# Altium schematic sheet SH2_BI-Gr_Potentiostat_USB_PwrIN_R0.05.00.SchDoc(SH2_BI-Gr_Potentiostat_USB_PwrIN_R0.05.00)
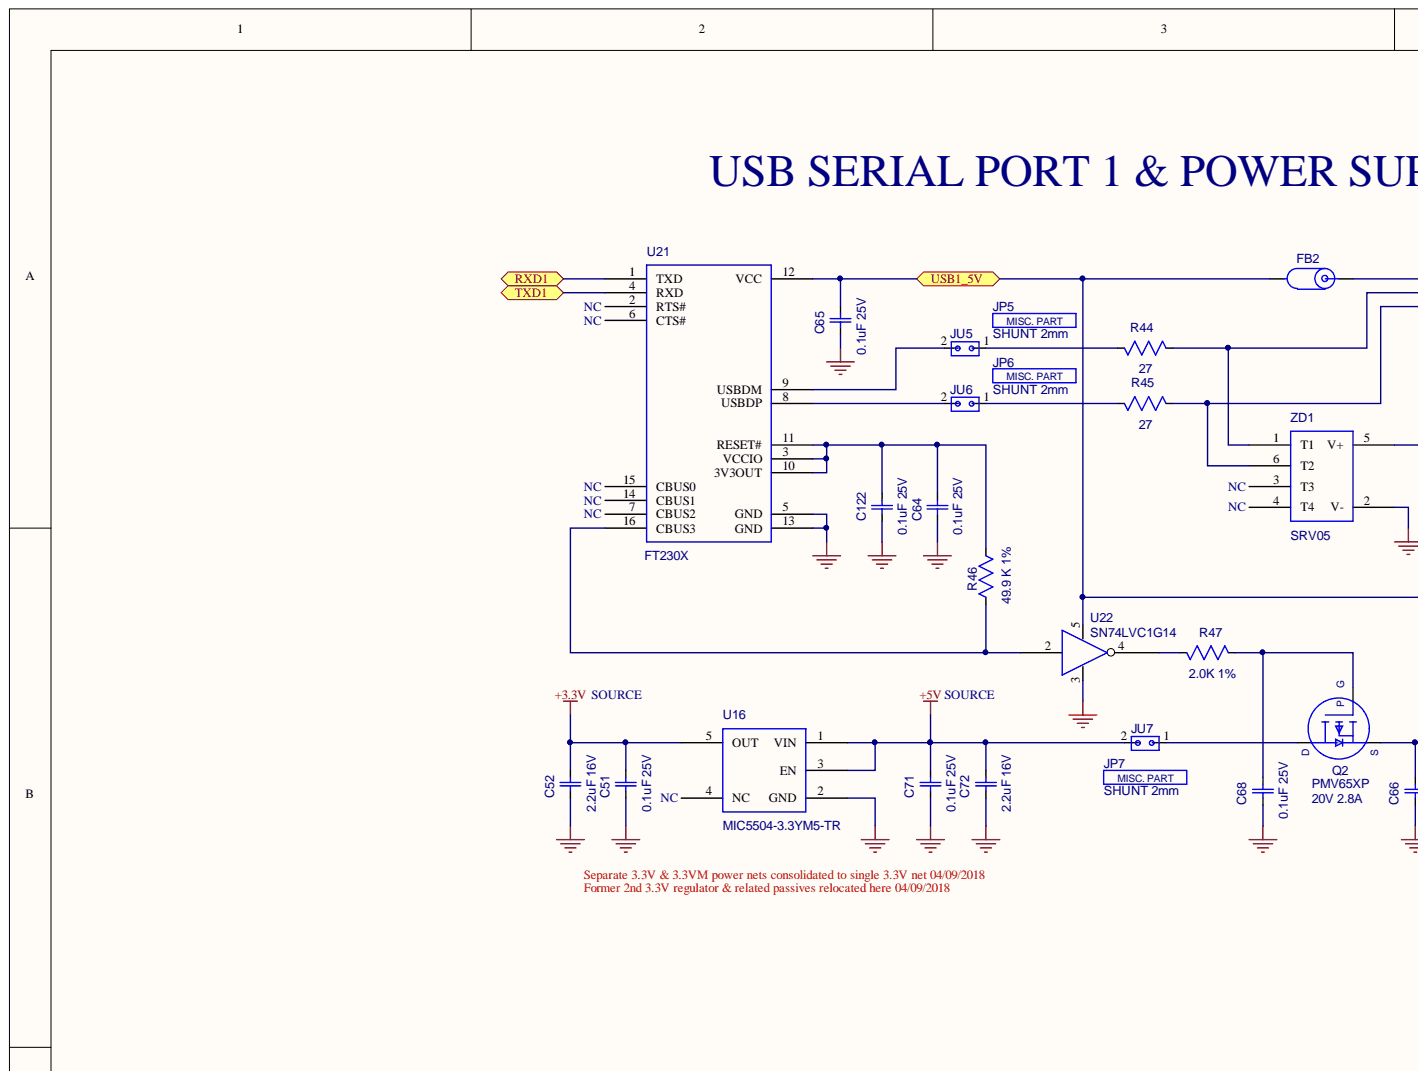
[diagram p0: SH2_BI-Gr_Potentiostat_USB_PwrIN_R0.05.00.SchDoc, top left]
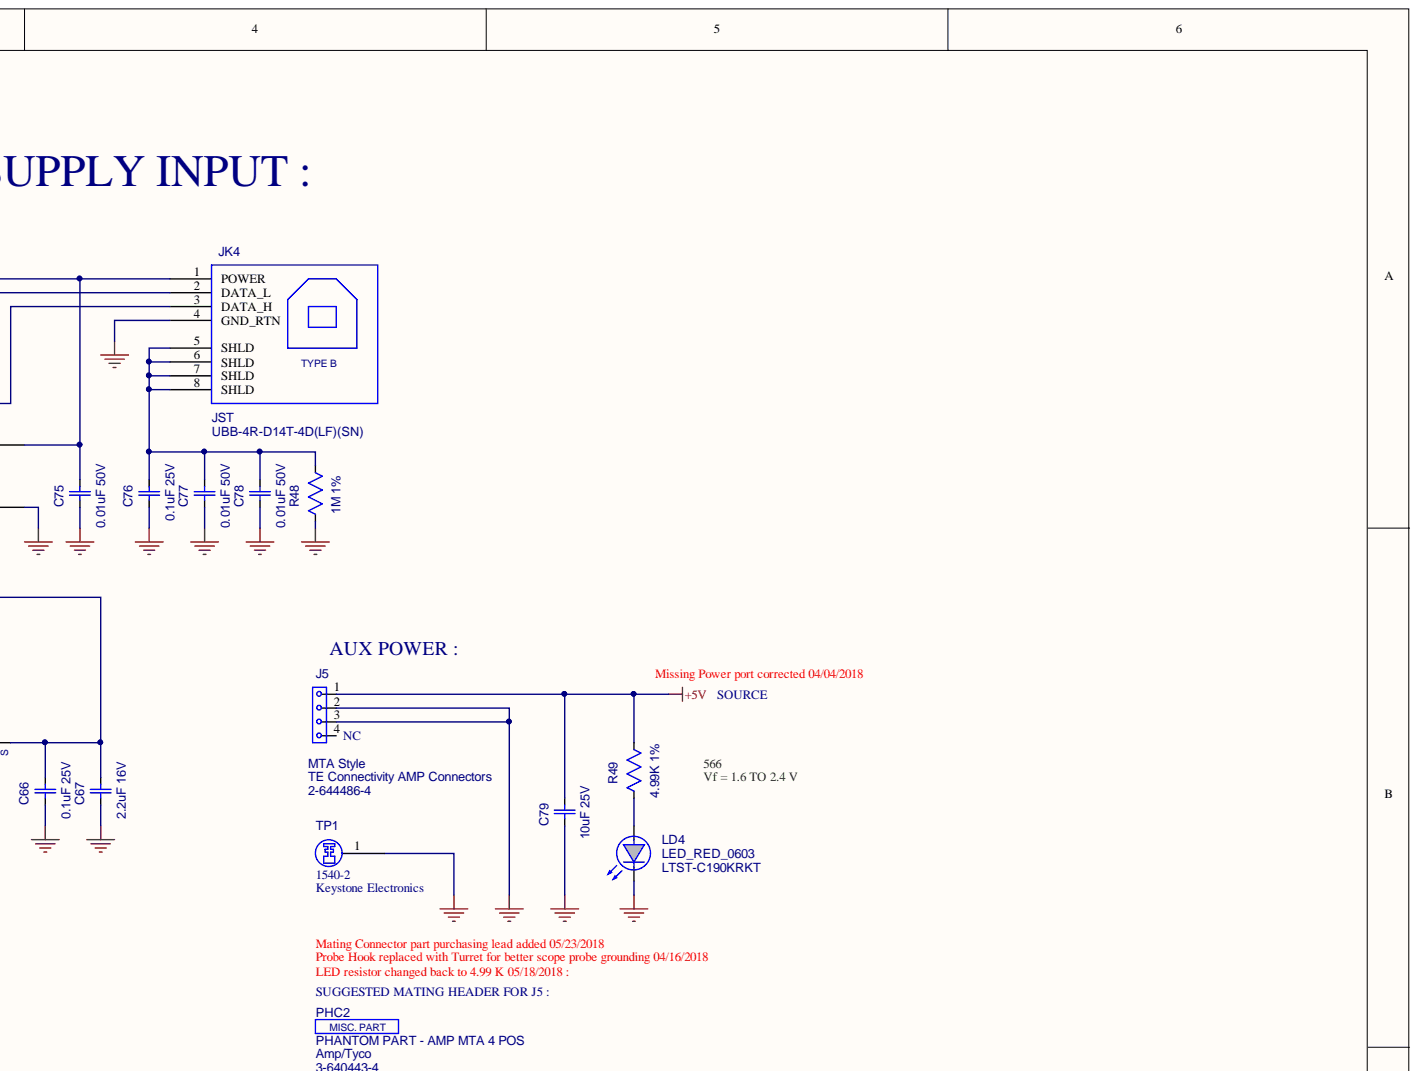
[diagram p1: SH2_BI-Gr_Potentiostat_USB_PwrIN_R0.05.00.SchDoc, top right]
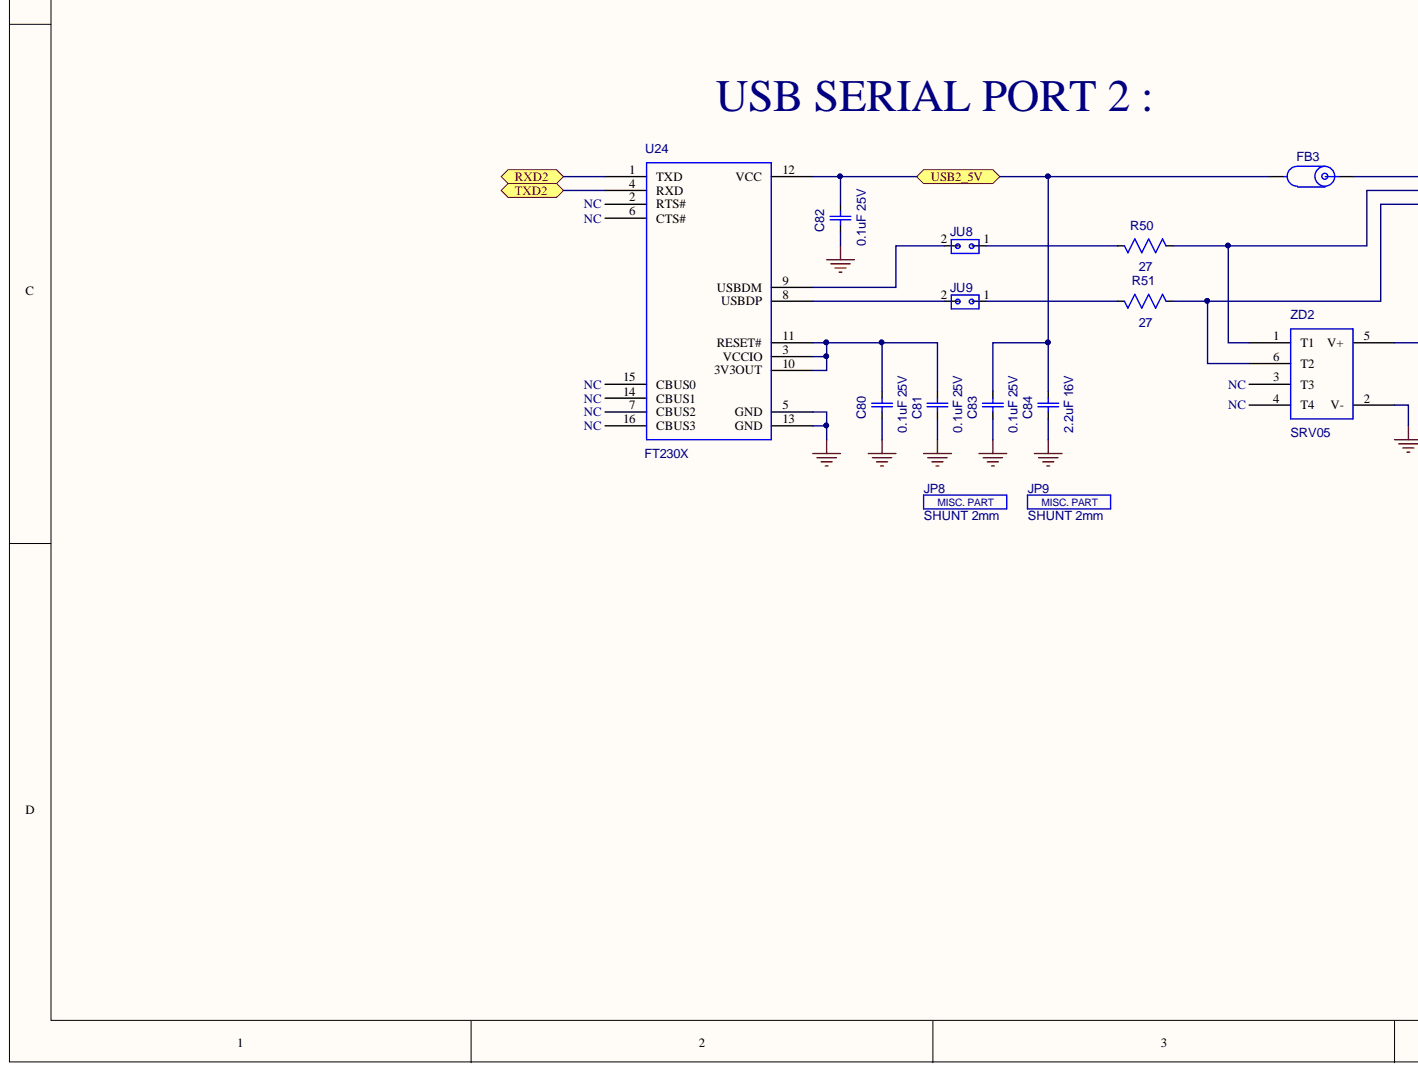
[diagram p2: SH2_BI-Gr_Potentiostat_USB_PwrIN_R0.05.00.SchDoc, bottom left]
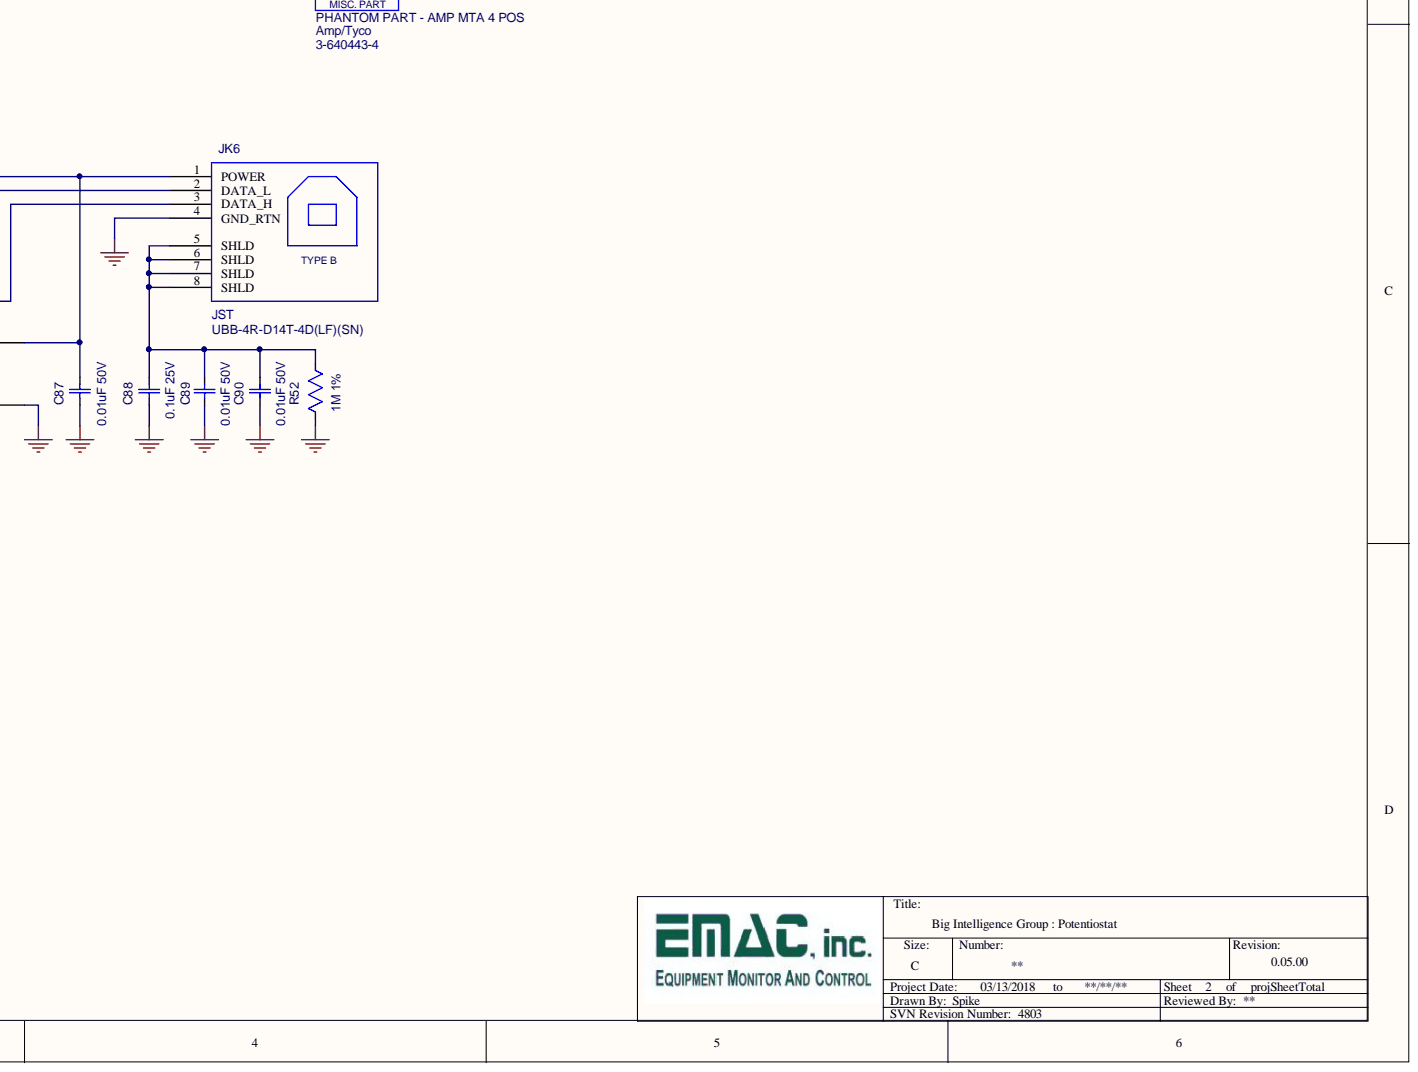
[diagram p3: SH2_BI-Gr_Potentiostat_USB_PwrIN_R0.05.00.SchDoc, bottom right]

## Parts
C51  0.1uF 25V  Notes: 25V  [CC0603_IPC_L]
C52  2.2uF 16V  value 2.2uF  Notes: 16V  [CC0603_IPC_L]
C64  0.1uF 25V  Notes: 25V  [CC0603_IPC_L]
C65  0.1uF 25V  Notes: 25V  [CC0603_IPC_L]
C66  0.1uF 25V  Notes: 25V  [CC0603_IPC_L]
C67  2.2uF 16V  value 2.2uF  Notes: 16V  [CC0603_IPC_L]
C68  0.1uF 25V  Notes: 25V  [CC0603_IPC_L]
C71  0.1uF 25V  Notes: 25V  [CC0603_IPC_L]
C72  2.2uF 16V  value 2.2uF  Notes: 16V  [CC0603_IPC_L]
C75  0.01uF 50V  value 0.01uF  Notes: 50V  [CC0603_IPC_L]
C76  0.1uF 25V  Notes: 25V  [CC0603_IPC_L]
C77  0.01uF 50V  value 0.01uF  Notes: 50V  [CC0603_IPC_L]
C78  0.01uF 50V  value 0.01uF  Notes: 50V  [CC0603_IPC_L]
C79  10uF 25V  value 10uF  Notes: 25V  [CC0805_IPC_L]
C80  0.1uF 25V  Notes: 25V  [CC0603_IPC_L]
C81  0.1uF 25V  Notes: 25V  [CC0603_IPC_L]
C82  0.1uF 25V  Notes: 25V  [CC0603_IPC_L]
C83  0.1uF 25V  Notes: 25V  [CC0603_IPC_L]
C84  2.2uF 16V  value 2.2uF  Notes: 16V  [CC0603_IPC_L]
C87  0.01uF 50V  value 0.01uF  Notes: 50V  [CC0603_IPC_L]
C88  0.1uF 25V  Notes: 25V  [CC0603_IPC_L]
C89  0.01uF 50V  value 0.01uF  Notes: 50V  [CC0603_IPC_L]
C90  0.01uF 50V  value 0.01uF  Notes: 50V  [CC0603_IPC_L]
C122  0.1uF 25V  Notes: 25V  [CC0603_IPC_L]
FB2  RFchoke 4.0A  [LC0603_IPC_L]  (value not drawn)
FB3  RFchoke 4.0A  [LC0603_IPC_L]  (value not drawn)
J5  2-644486-4  Notes: MTA Style  [CONN_VEHDR_AMP644486-4_PAT]
JK4  Manufacturer PN: UBB-4R-D14T-4D(LF)(SN)  Manufacturer: JST  [CONN_USB_HE_TYPEB_JST_PAT1]
JK6  Manufacturer PN: UBB-4R-D14T-4D(LF)(SN)  Manufacturer: JST  [CONN_USB_HE_TYPEB_JST_PAT1]
JP5  SHUNT 2mm  [SHUNT_2MM_PAT]
JP6  SHUNT 2mm  [SHUNT_2MM_PAT]
JP7  SHUNT 2mm  [SHUNT_2MM_PAT]
JP8  SHUNT 2mm  [SHUNT_2MM_PAT]
JP9  SHUNT 2mm  [SHUNT_2MM_PAT]
JU5  1x2_2mm  [2MMSHDR_02_PAT2]  (value not drawn)
JU6  1x2_2mm  [2MMSHDR_02_PAT2]  (value not drawn)
JU7  1x2_2mm  [2MMSHDR_02_PAT2]  (value not drawn)
JU8  1x2_2mm  [2MMSHDR_02_PAT2]  (value not drawn)
JU9  1x2_2mm  [2MMSHDR_02_PAT2]  (value not drawn)
LD4  LED_RED_0603  Manufacturer PN: LTST-C190KRKT  [LED_0603_RED_PAT]
PHC2  PHANTOM PART - AMP MTA 4 POS  Manfacturer PN: 3-640443-4  Manfacturer: Amp/Tyco  [PHANTOM_PAT1]
Q2  PMV65XP  value 20V 2.8A  Type: S  [SOT23_3_PMV65XP215]
R44  27  [RC0603_IPC_L]  (value not drawn)
R45  27  [RC0603_IPC_L]
R46  49.9 K 1%  [RC0603_IPC_L]
R47  2.0K 1%  [RC0603_IPC_L]
R48  1M 1%  [RC0603_IPC_L]
R49  4.99K 1%  [RC0603_IPC_L]
R50  27  [RC0603_IPC_L]  (value not drawn)
R51  27  [RC0603_IPC_L]
R52  1M 1%  [RC0603_IPC_L]
TP1  Manufacturer PN: 1540-2  Manufacturer: Keystone Electronics  [TURRETPAD_PAT1]  (hidden comment: GND_TURRET)
U16  MIC5504-3.3YM5-TR  [SOT23-5_MIC5504]
U21  FT230X  [TSSOP_16_PAT1]
U22  SN74LVC1G14  [SC70-5_SN74LVC1G14DCKR]
U24  FT230X  [TSSOP_16_PAT1]
ZD1  SRV05  [SRV05-4_PAT]
ZD2  SRV05  [SRV05-4_PAT]

## Ports
RXD1, RXD2, TXD1, TXD2, USB1_5V, USB2_5V

## Nets
+3.3V: C51.1 C52.1 U16.5(OUT)
+5V: C71.1 C72.1 C79.1 J5.1 JU7.2 R49.1 U16.1(VIN) U16.3(EN)
GND: C51.2 C52.2 C64.2 C65.2 C66.2 C67.2 C68.2 C71.2 C72.2 C75.2 C76.2 C77.2 C78.2 C79.2 C80.2 C81.2 C82.2 C83.2 C84.2 C87.2 C88.2 C89.2 C90.2 C122.2 J5.2 J5.3 JK4.4(GND_RTN) JK6.4(GND_RTN) LD4.1 R48.2 R52.2 TP1.1 U16.2(GND) U21.5(GND) U21.13(GND) U22.3 U24.5(GND) U24.13(GND) ZD1.2(V-) ZD2.2(V-)
RXD1: U21.1(TXD) ; port RXD1
RXD2: U24.1(TXD) ; port RXD2
TXD1: U21.4(RXD) ; port TXD1
TXD2: U24.4(RXD) ; port TXD2
USB1_5V: C65.1 C66.1 C67.1 FB2.2 Q2.2 U21.12(VCC) U22.5 ; port USB1_5V
USB2_5V: C82.1 C83.1 C84.1 FB3.2 U24.12(VCC) ; port USB2_5V
NetC64_1: C64.1 C122.1 R46.2 U21.3(VCCIO) U21.10(3V3OUT) U21.11(RESET#)
NetC68_1: C68.1 Q2.1 R47.1
NetC75_1: C75.1 FB2.1 JK4.1(POWER) ZD1.5(V+)
NetC76_1: C76.1 C77.1 C78.1 JK4.5(SHLD) JK4.6(SHLD) JK4.7(SHLD) JK4.8(SHLD) R48.1
NetC80_1: C80.1 C81.1 U24.3(VCCIO) U24.10(3V3OUT) U24.11(RESET#)
NetC87_1: C87.1 FB3.1 JK6.1(POWER) ZD2.5(V+)
NetC88_1: C88.1 C89.1 C90.1 JK6.5(SHLD) JK6.6(SHLD) JK6.7(SHLD) JK6.8(SHLD) R52.1
NetJK4_2: JK4.2(DATA_L) R44.1 ZD1.1(T1)
NetJK4_3: JK4.3(DATA_H) R45.1 ZD1.6(T2)
NetJK6_2: JK6.2(DATA_L) R50.1 ZD2.1(T1)
NetJK6_3: JK6.3(DATA_H) R51.1 ZD2.6(T2)
NetJU5_1: JU5.1 R44.2
NetJU5_2: JU5.2 U21.9(USBDM)
NetJU6_1: JU6.1 R45.2
NetJU6_2: JU6.2 U21.8(USBDP)
NetJU7_1: JU7.1 Q2.3
NetJU8_1: JU8.1 R50.2
NetJU8_2: JU8.2 U24.9(USBDM)
NetJU9_1: JU9.1 R51.2
NetJU9_2: JU9.2 U24.8(USBDP)
NetLD4_2: LD4.2 R49.2
NetR46_1: R46.1 U21.16(CBUS3) U22.2
NetR47_2: R47.2 U22.4

Not connected: J5 4; U16 4(NC); U21 2(RTS#) 6(CTS#) 7(CBUS2) 14(CBUS1) 15(CBUS0); U24 2(RTS#) 6(CTS#) 7(CBUS2) 14(CBUS1) 15(CBUS0) 16(CBUS3); ZD1 3(T3) 4(T4); ZD2 3(T3) 4(T4)

## Library data of the parts
C51 C64-C66 C68 C71 C76 C80-C83 C88 C122 = 09-90081: CCG^0.1uF   25V 0603   10% X7R; Manufacturer PN: C0603C104K3RAC7411; Manufacturer: Kemet; Value: .1 uF; type: S; Temp Range (°C): -55°C ~ 125°C; SVN Revision No.: 5061; Status Code: L; Options: 00; Database Table Name: passives; RoHS: YES; Part Number: 09-90081; Package: 0603; msl: 1; Library Name: MySQL_ALTIUM.DbLib; Height Max (mm): 0.90 mm; Component Kind: Standard; Author: Mike L.
C52 C67 C72 C84 = 09-90070: CCG^  2.2uF  16V 0603  10% X5R; type: S; SVN Revision No.: 5061; Status Code: L; Options: 00; Database Table Name: passives; Part Number: 09-90070; Package: 0603; msl: 1; Library Name: MySQL_ALTIUM.DbLib; Height Max (mm): 0.90 mm; Component Kind: Standard; Author: Mike L.
C75 C77 C78 C87 C89 C90 = 09-90004: CCG^0.01uF  50V 0603   10% X7R; Manufacturer PN: C0603C103K5RACTU; Manufacturer: Kemet; type: S; Temp Range (°C): -55°C ~ 125°C; SVN Revision No.: 5061; Status Code: L; Options: 00; Database Table Name: passives; RoHS: YES; Part Number: 09-90004; Package: 0603; msl: 1; Library Name: MySQL_ALTIUM.DbLib; Height Max (mm): 0.87 mm; Datasheet: http://www.kemet.com/datasheets&C0603C103K5RACTU; Component Kind: Standard; Author: Mike L.
C79 = 09-90041: CCG^ 10uF 25V   0805   10% X5R; Manufacturer PN: TMK212BBJ106KG-T; Manufacturer: TAIYO YUDEN; type: S; Temp Range (°C): -55°C ~ 85°C; SVN Revision No.: 2227; Status Code: L; Options: 00; Database Table Name: passives; RoHS: YES; Part Number: 09-90041; Package: 0805; msl: 1; Library Name: MySQL_ALTIUM.DbLib; Last Updated: 5/21/15; Height Max (mm): 1.25 mm; Datasheet: http://www.yuden.co.jp/productdata/catalog/en/mlcc_all_e.pdf; Component Kind: Standard; Author: Mike L.
FB2 FB3 = 08-90016: IBF^RFchoke 4.0A      SM 0603; Manufacturer PN: HI0603P600R-10; Manufacturer: Laird-Signal Integrity Products; type: S; SVN Revision No.: 2417; Status Code: L; Options: 00; Notes: Imax=4A, Rdc=30mOhm, Z=60Ohm@100MHz; Database Table Name: passives; RoHS: YES; Part Number: 08-90016; Package: 0603; msl: 1; Library Name: MySQL_ALTIUM.DbLib; Height Max (mm): 0.95 mm; Datasheet: http://www.lairdtech.com/WorkArea/linkit.aspx?LinkIdentifier=id&ItemID=4843; Component Kind: Standard; Author: Mike L.
J5 = 02-90098: NHD_SVE 4 Pos Amp 2-644486-4; Manufacturer: TE Connectivity AMP Connectors; Value: {Value}; Temp Range (°C): -55°C ~ 105°C; SVN Revision No.: 558; Status Code: L; Options: 00; Information: {Information}; Database Table Name: connectors; Type: M; RoHS: YES; Part Number: 02-90098; MSL: 1; Manufacturer PN: 2-644486-4; Library Name: MySQL_ALTIUM.DbLib; Height Max (mm): 10.41 mm; Datasheet: http://www.te.com/commerce/DocumentDelivery/DDEController?Action=srchrtrv&DocNm=644486&DocType=Customer+Drawing&DocLang=English; Component Kind: Standard; ComponentHeight: {ComponentHeight}; Author: TM
JK4 JK6 = 02-90124: NMI^JHE USB type B; Part Number: {Part Number}; Value: {Value}; Temp Range (°C): {Temp Range}; SVN Revision No.: 3393; Status Code: L; Options: 00; Notes: {Notes}; ManfacturerPN: {ManfacturerPN}; Information: {Information}; Database Table Name: connectors; RoHS: YES; Part Number: 02-90124; MSL: 1; Library Name: MySQL_ALTIUM.DbLib; Last Updated: 1/13/16; Height Max (mm): 11.00 mm; Datasheet: http://datasheet.octopart.com/UBB-4R-D14T-4D%28LF%29%28SN%29-JST-datasheet-8332126.pdf; Component Kind: Standard; ComponentHeight: {ComponentHeight}; Author: Mike L.
JP5-JP9 = 02-0701: SHUNT^HDR MICRO 2MM; Manufacturer PN: 86730-101LF; Manufacturer: FCI; Temp Range (°C): {Temp Range}; SVN Revision No.: 2917; Status Code: L; Options: 00; Notes: {Notes}; Information: {Information}; Database Table Name: connectors; Type: M; RoHS: YES; Part Number: 02-0701; MSL: 1; Library Name: MySQL_ALTIUM.DbLib; Height Max (mm): 3.50 mm; Component Kind: Standard; Author: Mike L.
JU5-JU9 = 20-1502: NHD^MPM SR 1X2 2mm TH PRE; Manufacturer: EMAC inc.; Temp Range (°C): -45C ~ 105C; SVN Revision No.: 4614; Status Code: N; Options: 00; Database Table Name: connectors; Type: M; RoHS: YES; Part Number: 20-1502; MSL: 1; Library Name: MySQL_ALTIUM.DbLib; Height Max (mm): 5.80 mm; Component Kind: Standard; Author: TM
LD4 = 07-90034: LED^RED CLEAR SMT 0603; Manufacturer: Lite-On Inc; Value: Red; Type: S; Temp Range (°C): -55°C ~ 85°C; SVN Revision No.: 1348; Status Code: A; Options: 00; Notes: Vf=2V, Imax=20mA; Database Table Name: actives; ROHS: YES; Part Number: 07-90034; Package: 0603; MSL: 3; Library Name: MySQL_ALTIUM.DbLib; Height Max (mm): 0.90 mm; Datasheet: http://optoelectronics.liteon.com/en-us/api/DwonloadFileHandler.ashx?txtSpecNo=DS-22-99-0151&txtPartNo=LTST-C190KRKT; Component Kind: Standard; Author: JP
PHC2 = 26: PHANTOM PART W/O PNP; Part Number: 00-NON-INVNTORY; SVN Revision No.: 2917; Options: 98; Library Name: MySQL_ALTIUM.DbLib; id: 26; Database Table Name: phantom; Component Kind: Standard; Author: {Author}
Q2 = 05-90011: Mosfet^P Channel PMV65XP  SOT; Manufacturer PN: PMV65XP,215; Manufacturer: NXP Semiconductors; Temp Range (°C): -55,  +150; SVN Revision No.: 2815; Status Code: L; Options: 00; Notes: Vth=950mV, Rds=76mOhm@2.8A, Vds=20V, P=1.92W, Id=3.9A; Database Table Name: actives; ROHS: YES; Part Number: 05-90011; Package: SOT-23-3; MSL: 1; Library Name: MySQL_ALTIUM.DbLib; Height Max (mm): 1.10 mm; Datasheet: http://www.nxp.com/documents/data_sheet/PMV65XP.pdf; Component Kind: Standard; Author: Mike L.
R44 R45 R50 R51 = 10-90159: RCP_   27  ohm 5% 0.100W  0603; SVN Revision No.: 3043; Status Code: L; Options: 00; Database Table Name: resistors; Value: 27; Type: S; Part Number: 10-90159; Package: 0603; MSL: 1; Library Name: MySQL_ALTIUM.DbLib; Height Max (mm): 0.55 mm; Component Kind: Standard; Author: Mike L.
R46 = 10-90222: RCP^ 49.9 Kohm 1% 0.100W  0603; Manufacturer PN: 302-49.9K-RC; Manufacturer: Xicon; Temp Range (°C): -55°C ~ 125°C; SVN Revision No.: 3043; Status Code: L; Options: 00; Database Table Name: resistors; Value: 49.9K 1%; Type: S; RoHS: YES; Part Number: 10-90222; Package: 0603; MSL: 1; Library Name: MySQL_ALTIUM.DbLib; Height Max (mm): 0.55 mm; Datasheet: http://www.mouser.com/ds/2/351/RoyalElectronic_XC-600037-469831.pdf; Component Kind: Standard; Author: Mike L.
R47 = 10-90408: RCP^   2.0Kohm 1% 0.100W  0603; Manufacturer PN: RC0603FR-072KL; Manufacturer: Yageo; Temp Range (°C): -55°C ~ 155°C; SVN Revision No.: 3043; Status Code: P; Options: 00; Database Table Name: resistors; Value: 2.0K 1%; Type: S; RoHS: YES; Part Number: 10-90408; Package: 0603; MSL: 1; Library Name: MySQL_ALTIUM.DbLib; Last Updated: 9/16/16; Height Max (mm): 0.55 mm; Datasheet: http://www.yageo.com/documents/recent/PYu-RC_Group_51_RoHS_L_04.pdf; Component Kind: Standard; Author: ML
R48 R52 = 10-90061: RCP^ 1M ohm 1% 0.100W 0603; Manufacturer PN: RC0603FR-071ML; Manufacturer: Yageo; Temp Range (°C): -55°C ~ 155°C; SVN Revision No.: 3043; Status Code: L; Options: 00; Database Table Name: resistors; Value: 1M 1%; Type: S; RoHS: YES; Part Number: 10-90061; Package: 0603; MSL: 1; Library Name: MySQL_ALTIUM.DbLib; Height Max (mm): 0.55 mm; Datasheet: http://www.yageo.com.tw/exep/pages/download/literatures/PYu-R_INT-thick_7.pdf; Component Kind: Standard; Author: Mike L.
R49 = 10-90075: RCP^ 4.99K ohm 1% 0.062W  0603; SVN Revision No.: 3043; Status Code: L; Options: 00; Database Table Name: resistors; Value: 4.99K 1%; Type: S; Part Number: 10-90075; Package: 0603; MSL: 1; Library Name: MySQL_ALTIUM.DbLib; Height Max (mm): 0.55 mm; Component Kind: Standard; Author: Mike L.
TP1 = 03-0070: TEST^TERMINAL TURRET; Temp Range (°C): -40°C ~ 85°C; SVN Revision No.: 4713; Status Code: L; Options: 00; Database Table Name: misc; Type: M; RoHS: YES; Part Number: 03-0070; Package: TH; MSL: 1; Library Name: MySQL_ALTIUM.DbLib; Last Updated: 4/16/18; Height Max (mm): 6.76 mm; Datasheet: http://www.keyelco.com/product-pdf.cfm?p=3381; Component Kind: Standard; Author: ML
U16 = 06-90627: UAN^LR 3.3V 300mA LoDp SOT23-5; Manufacturer: Microchip Technology; Temp Range (°C): -40°C ~ 125°C; SVN Revision No.: 4609; Status Code: N; Options: 00; Notes: Vin=2.5V~5.5V, Vout=3.3V, Iout=300mA; Database Table Name: ics; Type: S; RoHS: YES; Part Number: 06-90627; Package: SOT23-5; MSL: 1; Manufacturer PN: MIC5504-3.3YM5-TR; Library Name: MySQL_ALTIUM.DbLib; Height Max (mm): 1.45 mm; Datasheet: http://www.microchip.com/mymicrochip/filehandler.aspx?ddocname=en580292; Component Kind: Standard; Author: TM
U21 U24 = 06-90401: UIF^FT USB->UART        SSOP16; Manufacturer PN: FT230XS-U; Manufacturer: FTDI; Temp Range (°C): -40 to +85 C; SVN Revision No.: 558; Status Code: L; Options: 00; Notes: Vs=3.3V~5V, Is=8mA; Database Table Name: ics; Type: S; RoHS: YES; Part Number: 06-90401; Package: SSOP-16; MSL: 3; Library Name: MySQL_ALTIUM.DbLib; Height Max (mm): 1.75 mm; Datasheet: http://www.ftdichip.com/Support/Documents/DataSheets/ICs/DS_FT230X.pdf; Component Kind: Standard; Author: ML
U22 = 06-90626: UDL^GL 1-Inv 74LVC1G14  SC70-5; Manufacturer PN: SN74LVC1G14DCKR; Manufacturer: Texas Instruments; Temp Range (°C): -40°C ~ 125°C; SVN Revision No.: 2529; Status Code: N; Options: 00; Notes: Vs=1.65V~5.5V, VL=0.39V~1.87V, VH=1.16V~3.33V, Iout=32mA; Database Table Name: ics; Type: S; RoHS: YES; Part Number: 06-90626; Package: SC-70-5; MSL: 1; Library Name: MySQL_ALTIUM.DbLib; Height Max (mm): 1.10 mm; Datasheet: http://www.ti.com/lit/ds/symlink/sn74lvc1g14.pdf; Component Kind: Standard; Author: TM
ZD1 ZD2 = 15-90012: ECA^4x15KV 40A 3pF SMD SOT23-6; Manufacturer PN: SRV05-4.TCT; Manufacturer: Semtech; Temp Range (°C): -55°C ~ 125°C; SVN Revision No.: 665; Status Code: L; Options: 00; Notes: Vbr=6V, P=300W,; Database Table Name: misc; Type: S; RoHS: YES; Part Number: 15-90012; Package: SOT23-6; MSL: 1; Library Name: MySQL_ALTIUM.DbLib; Last Updated: 9/15/16; Height Max (mm): 1.45 mm; Datasheet: http://www.semtech.com/images/datasheet/srv05-4.pdf; Component Kind: Standard; Author: Mike L.
